# Altium schematic sheet pay-led-pcb.SchDoc
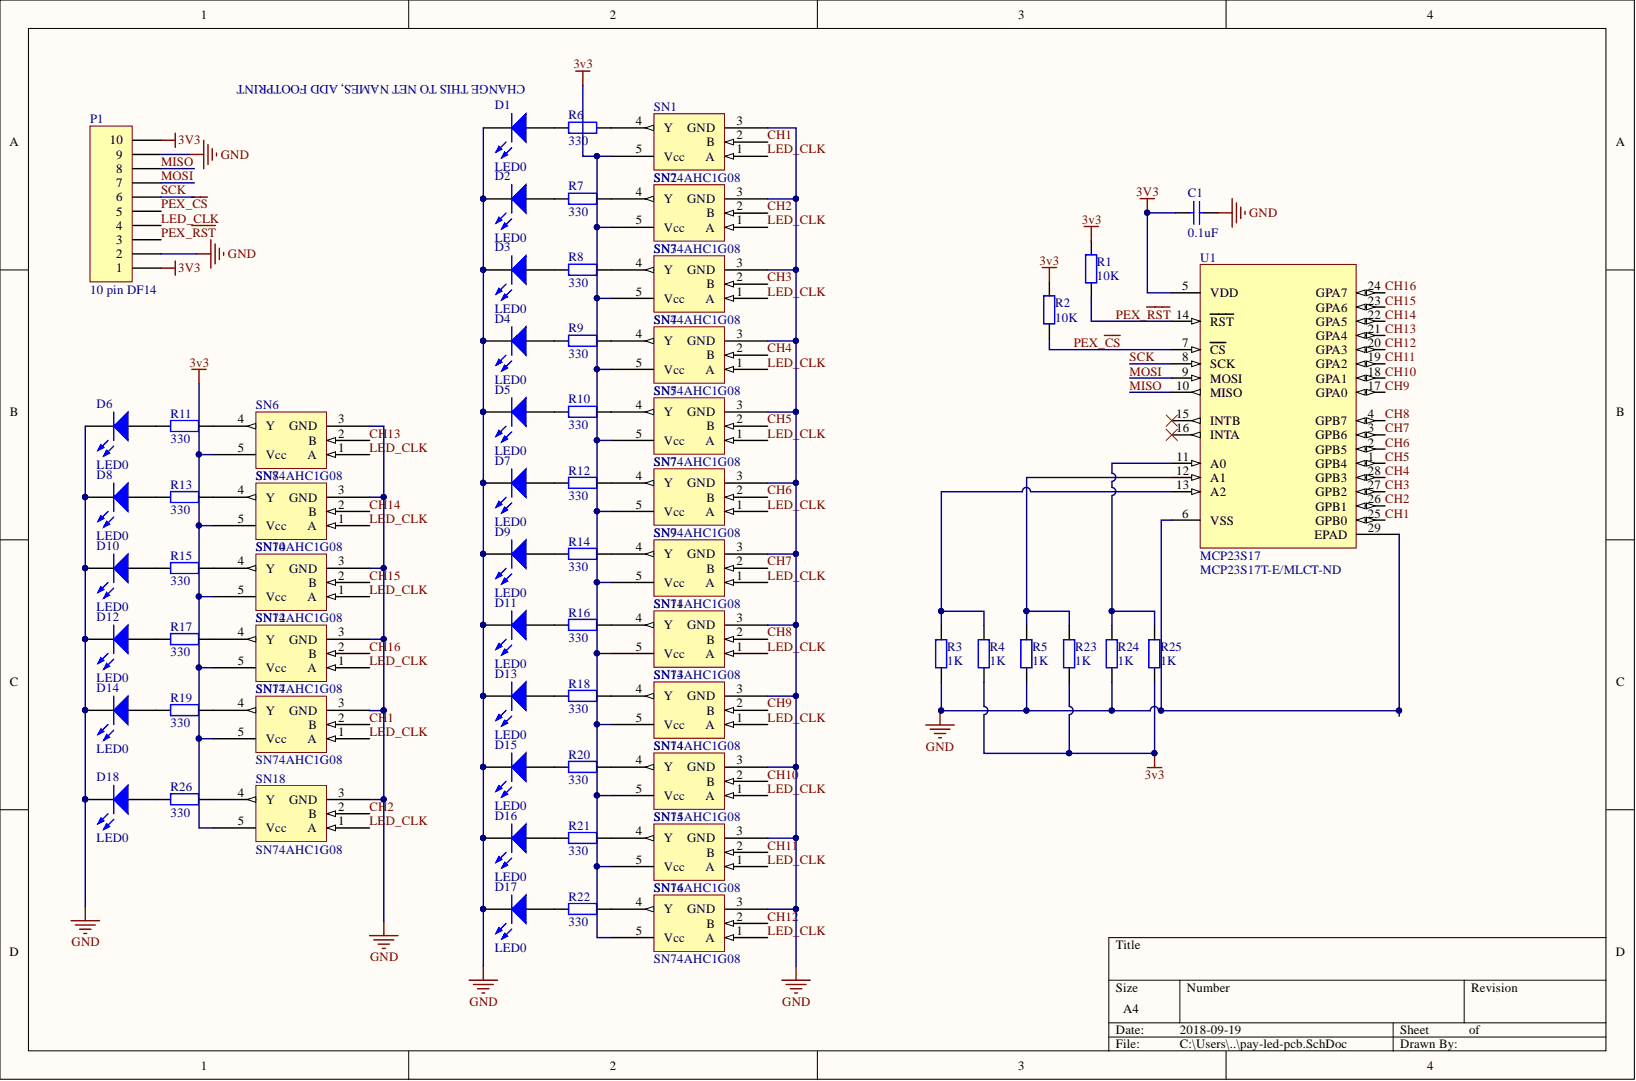
[diagram p0: pay-led-pcb.SchDoc]

## Parts
C1  0.1uF  [1608[0603]]
D1  LED0  [LB P4SG]
D2  LED0  [LB P4SG]
D3  LED0  [LB P4SG]
D4  LED0  [LB P4SG]
D5  LED0  [LB P4SG]
D6  LED0  [LB P4SG]
D7  LED0  [LB P4SG]
D8  LED0  [LB P4SG]
D9  LED0  [LB P4SG]
D10  LED0  [LB P4SG]
D11  LED0  [LB P4SG]
D12  LED0  [LB P4SG]
D13  LED0  [LB P4SG]
D14  LED0  [LB P4SG]
D15  LED0  [LB P4SG]
D16  LED0  [LB P4SG]
D17  LED0  [LB P4SG]
D18  LED0  [LB P4SG]
P1  10 pin DF14  [DF14-10P-1.25H(55)]
R1  10K  [J1-0603]
R2  10K  [J1-0603]
R3  1K  [J1-0603]
R4  1K  [J1-0603]
R5  1K  [J1-0603]
R6  330  [J1-0603]
R7  330  [J1-0603]
R8  330  [J1-0603]
R9  330  [J1-0603]
R10  330  [J1-0603]
R11  330  [J1-0603]
R12  330  [J1-0603]
R13  330  [J1-0603]
R14  330  [J1-0603]
R15  330  [J1-0603]
R16  330  [J1-0603]
R17  330  [J1-0603]
R18  330  [J1-0603]
R19  330  [J1-0603]
R20  330  [J1-0603]
R21  330  [J1-0603]
R22  330  [J1-0603]
R23  1K  [J1-0603]
R24  1K  [J1-0603]
R25  1K  [J1-0603]
R26  330  [J1-0603]
SN1  SN74AHC1G08  [SOT-23-5_95P290X160-5N]
SN2  SN74AHC1G08  [SOT-23-5_95P290X160-5N]
SN3  SN74AHC1G08  [SOT-23-5_95P290X160-5N]
SN4  SN74AHC1G08  [SOT-23-5_95P290X160-5N]
SN5  SN74AHC1G08  [SOT-23-5_95P290X160-5N]
SN6  SN74AHC1G08  [SOT-23-5_95P290X160-5N]
SN7  SN74AHC1G08  [SOT-23-5_95P290X160-5N]
SN8  SN74AHC1G08  [SOT-23-5_95P290X160-5N]
SN9  SN74AHC1G08  [SOT-23-5_95P290X160-5N]
SN10  SN74AHC1G08  [SOT-23-5_95P290X160-5N]
SN11  SN74AHC1G08  [SOT-23-5_95P290X160-5N]
SN12  SN74AHC1G08  [SOT-23-5_95P290X160-5N]
SN13  SN74AHC1G08  [SOT-23-5_95P290X160-5N]
SN14  SN74AHC1G08  [SOT-23-5_95P290X160-5N]
SN15  SN74AHC1G08  [SOT-23-5_95P290X160-5N]
SN16  SN74AHC1G08  [SOT-23-5_95P290X160-5N]
SN17  SN74AHC1G08  [SOT-23-5_95P290X160-5N]
SN18  SN74AHC1G08  [SOT-23-5_95P290X160-5N]
U1  MCP23S17  Supplier Part Number 1: MCP23S17T-E/MLCT-ND  [QFN65P600X600X100-29N]

## Nets
3V3: C1.2 P1.1 P1.10 R1.1 R2.1 R4.1 R23.1 R25.1 SN1.5(Vcc) SN2.5(Vcc) SN3.5(Vcc) SN4.5(Vcc) SN5.5(Vcc) SN6.5(Vcc) SN7.5(Vcc) SN8.5(Vcc) SN9.5(Vcc) SN10.5(Vcc) SN11.5(Vcc) SN12.5(Vcc) SN13.5(Vcc) SN14.5(Vcc) SN15.5(Vcc) SN16.5(Vcc) SN17.5(Vcc) SN18.5(Vcc) U1.5(VDD)
GND: C1.1 D1.2 D2.2 D3.2 D4.2 D5.2 D6.2 D7.2 D8.2 D9.2 D10.2 D11.2 D12.2 D13.2 D14.2 D15.2 D16.2 D17.2 D18.2 P1.2 P1.9 R3.1 R5.1 R24.1 SN1.3(GND) SN2.3(GND) SN3.3(GND) SN4.3(GND) SN5.3(GND) SN6.3(GND) SN7.3(GND) SN8.3(GND) SN9.3(GND) SN10.3(GND) SN11.3(GND) SN12.3(GND) SN13.3(GND) SN14.3(GND) SN15.3(GND) SN16.3(GND) SN17.3(GND) SN18.3(GND) U1.6(VSS) U1.29(EPAD)
CH1: SN1.2(B) SN17.2(B) U1.25(GPB0)
CH2: SN2.2(B) SN18.2(B) U1.26(GPB1)
CH3: SN3.2(B) U1.27(GPB2)
CH4: SN4.2(B) U1.28(GPB3)
CH5: SN5.2(B) U1.1(GPB4)
CH6: SN7.2(B) U1.2(GPB5)
CH7: SN9.2(B) U1.3(GPB6)
CH8: SN11.2(B) U1.4(GPB7)
CH9: SN13.2(B) U1.17(GPA0)
CH10: SN14.2(B) U1.18(GPA1)
CH11: SN15.2(B) U1.19(GPA2)
CH12: SN16.2(B) U1.20(GPA3)
CH13: SN6.2(B) U1.21(GPA4)
CH14: SN8.2(B) U1.22(GPA5)
CH15: SN10.2(B) U1.23(GPA6)
CH16: SN12.2(B) U1.24(GPA7)
LED_CLK: P1.4 SN1.1(A) SN2.1(A) SN3.1(A) SN4.1(A) SN5.1(A) SN6.1(A) SN7.1(A) SN8.1(A) SN9.1(A) SN10.1(A) SN11.1(A) SN12.1(A) SN13.1(A) SN14.1(A) SN15.1(A) SN16.1(A) SN17.1(A) SN18.1(A)
MISO: P1.8 U1.10(MISO)
MOSI: P1.7 U1.9(MOSI)
PEX_~{CS}: P1.5 R2.2 U1.7(CS)
PEX_~{RST}: P1.3 R1.2 U1.14(RST)
SCK: P1.6 U1.8(SCK)
NetD1_1: D1.1 R6.1
NetD2_1: D2.1 R7.1
NetD3_1: D3.1 R8.1
NetD4_1: D4.1 R9.1
NetD5_1: D5.1 R10.1
NetD6_1: D6.1 R11.1
NetD7_1: D7.1 R12.1
NetD8_1: D8.1 R13.1
NetD9_1: D9.1 R14.1
NetD10_1: D10.1 R15.1
NetD11_1: D11.1 R16.1
NetD12_1: D12.1 R17.1
NetD13_1: D13.1 R18.1
NetD14_1: D14.1 R19.1
NetD15_1: D15.1 R20.1
NetD16_1: D16.1 R21.1
NetD17_1: D17.1 R22.1
NetD18_1: D18.1 R26.1
NetR3_2: R3.2 R4.2 U1.13(A2)
NetR5_2: R5.2 R23.2 U1.12(A1)
NetR6_2: R6.2 SN1.4(Y)
NetR7_2: R7.2 SN2.4(Y)
NetR8_2: R8.2 SN3.4(Y)
NetR9_2: R9.2 SN4.4(Y)
NetR10_2: R10.2 SN5.4(Y)
NetR11_2: R11.2 SN6.4(Y)
NetR12_2: R12.2 SN7.4(Y)
NetR13_2: R13.2 SN8.4(Y)
NetR14_2: R14.2 SN9.4(Y)
NetR15_2: R15.2 SN10.4(Y)
NetR16_2: R16.2 SN11.4(Y)
NetR17_2: R17.2 SN12.4(Y)
NetR18_2: R18.2 SN13.4(Y)
NetR19_2: R19.2 SN17.4(Y)
NetR20_2: R20.2 SN14.4(Y)
NetR21_2: R21.2 SN15.4(Y)
NetR22_2: R22.2 SN16.4(Y)
NetR24_2: R24.2 R25.2 U1.11(A0)
NetR26_2: R26.2 SN18.4(Y)

Not connected: U1 15(INTB) 16(INTA)

## Library data of the parts
C1 = Cap: Capacitor; Component Kind: Standard; LatestRevisionDate: 17-Jul-2002; LatestRevisionNote: Re-released for DXP Platform.; Library Name: Miscellaneous Devices.IntLib; PackageDescription: Radial Cap, Thru-Hole; 2 Leads; 0.3 in Pin Spacing; PackageReference: RAD-0.3; Published: 8-Jun-2000; Signal Integrity: Cap; Simulation: CAP; Supplier: Digi-Key; Supplier Part Number 1: 311-1341-1-ND; Value: 0.1uF; Publisher: Altium Limited
D1-D18 = LED0: Typical INFRARED GaAs LED; Component Kind: Standard; LatestRevisionDate: 08-Jul-2005; LatestRevisionNote: Mech Layer 1 removed.; Library Name: Miscellaneous Devices.IntLib; PackageDescription: LED; 2 Leads; PackageReference: LED-0; Published: 8-Jun-2000; Simulation: LED0; Supplier: Digi-Key; Supplier Part Number 1: 475-1316-1-ND; Publisher: Altium Limited
P1 = Header 10: Header, 10-Pin; Component Kind: Standard; LatestRevisionDate: 17-Jul-2002; LatestRevisionNote: Re-released for DXP Platform.; Library Name: Miscellaneous Connectors.IntLib; Signal Integrity: Connector; Supplier: Digi-Key; Supplier Part Number 1: H10329CT-ND; Publisher: Altium Limited
R1-R26 = Res2: Resistor; Component Kind: Standard; LatestRevisionDate: 17-Jul-2002; LatestRevisionNote: Re-released for DXP Platform.; Library Name: Miscellaneous Devices.IntLib; PackageDescription: Axial Device, Thru-Hole; 2 Leads; 0.4 in Pin Spacing; PackageReference: AXIAL-0.4; Published: 8-Jun-2000; Signal Integrity: Res; Simulation: RESISTOR; Supplier: Digi-Key; Publisher: Altium Limited
  R1 R2: Supplier Part Number 1: RMCF0603JG10K0CT-ND; Value: 10K
  R3-R5 R23-R25: Supplier Part Number 1: RNCP0603FTD1K00CT-ND; Value: 1K
  R6-R22 R26: Supplier Part Number 1: 1276-4604-1-ND; Value: 330
U1 = MCP23S17: 16-bit I/O expander.; Component Kind: Standard; Supplier: Digi-Key; Library Name: PAY.SchLib
SN1-SN18 = SN74AHC1G08: Component Kind: Standard; Library Name: MCP23S17.SchLib; Supplier: Digi-Key; Supplier Part Number 1: 296-23875-1-ND
